# Altium schematic sheet Temp Sensor_DAC_Speed_Monitor.SchDoc
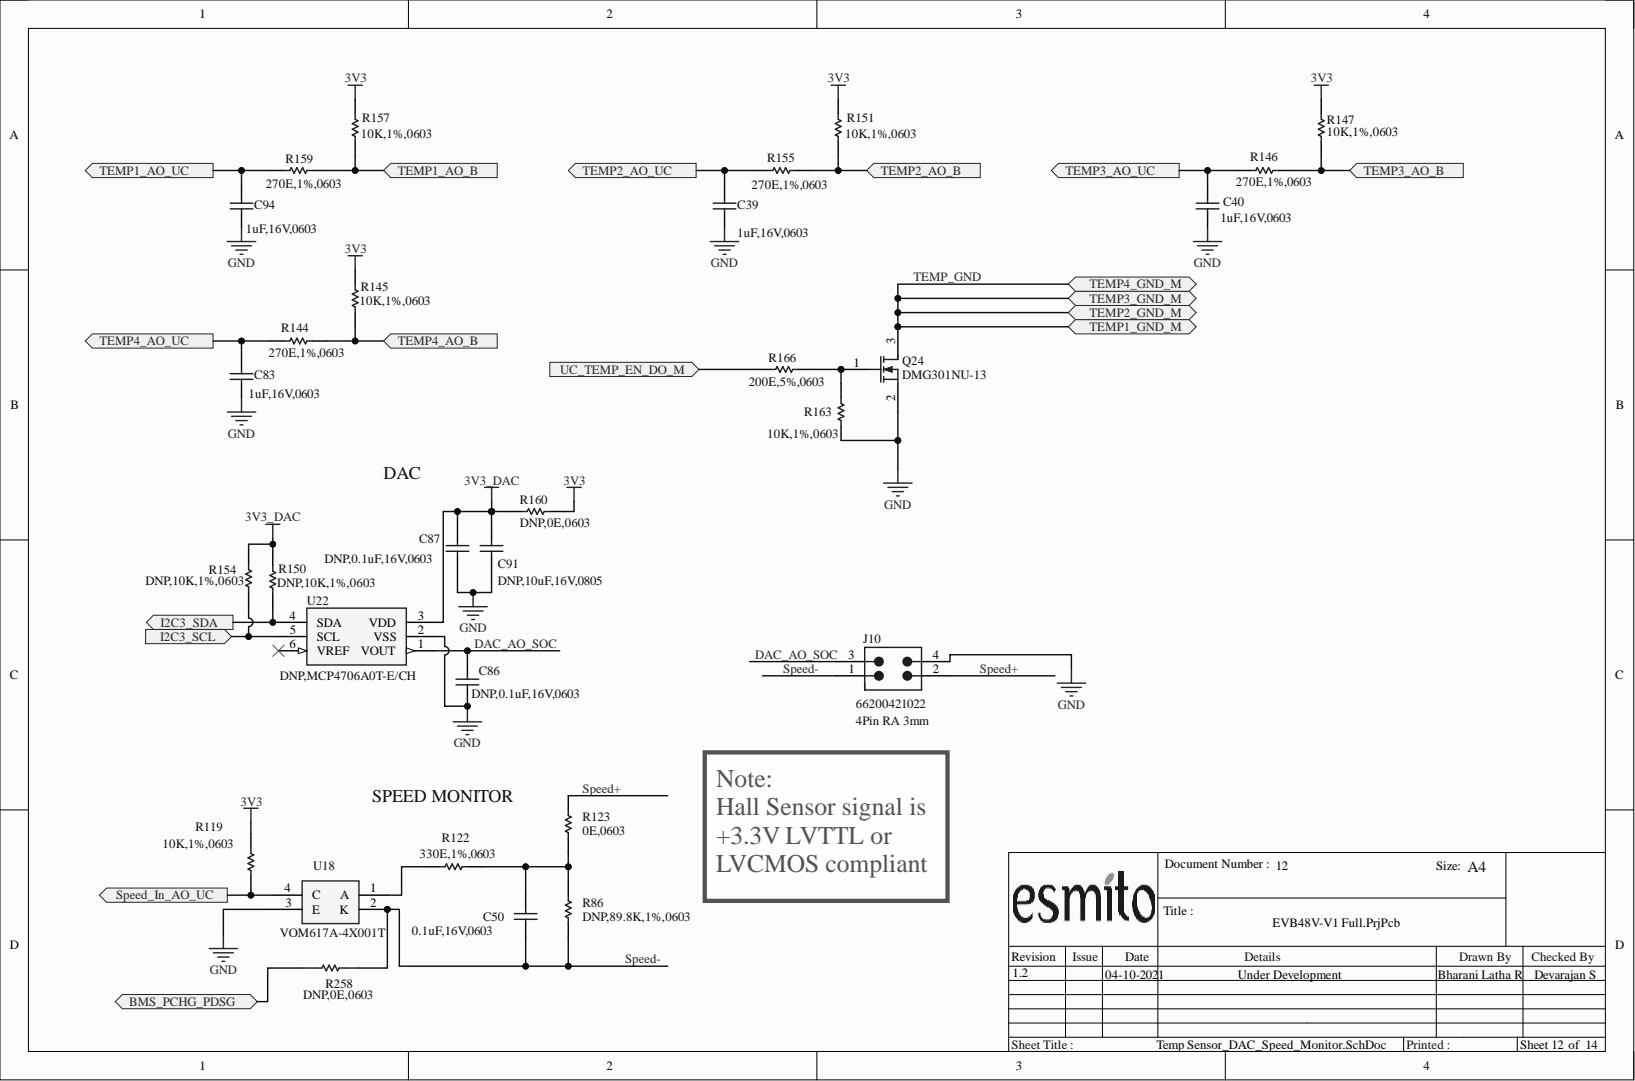
[diagram p0: Temp Sensor_DAC_Speed_Monitor.SchDoc]

## Parts
C39  value 1uF,16V,0603  [0603_C_M]  (hidden comment: C_Semi)
C40  value 1uF,16V,0603  [0603_C_M]  (hidden comment: C_Semi)
C50  value 0.1uF,16V,0603  [0603_C_M]  (hidden comment: C_Semi)
C83  value 1uF,16V,0603  [0603_C_M]  (hidden comment: C_Semi)
C86  value DNP,0.1uF,16V,0603  [0603_C_M]  (hidden comment: C_Semi)
C87  value DNP,0.1uF,16V,0603  [0603_C_M]  (hidden comment: C_Semi)
C91  value DNP,10uF,16V,0805  [0805_R_M]  (hidden comment: C_Semi)
C94  value 1uF,16V,0603  [0603_C_M]  (hidden comment: C_Semi)
J10  4Pin RA 3mm  value 66200421022  [66200421022]
Q24  value DMG301NU-13  Part Number: DMG301NU-13  [SOT23_3_M]  (hidden comment: MOS-N_E)
R86  value DNP,89.8K,1%,0603  [0603_R_M]  (hidden comment: R_Semi)
R119  value 10K,1%,0603  [0603_R_M]  (hidden comment: R_Semi)
R122  value 330E,1%,0603  [0603_R_M]  (hidden comment: R_Semi)
R123  value 0E,0603  [0603_R_M]  (hidden comment: R_Semi)
R144  value 270E,1%,0603  [0603_R_M]  (hidden comment: R_Semi)
R145  value 10K,1%,0603  [0603_R_M]  (hidden comment: R_Semi)
R146  value 270E,1%,0603  [0603_R_M]  (hidden comment: R_Semi)
R147  value 10K,1%,0603  [0603_R_M]  (hidden comment: R_Semi)
R150  value DNP,10K,1%,0603  [0603_R_M]  (hidden comment: R_Semi)
R151  value 10K,1%,0603  [0603_R_M]  (hidden comment: R_Semi)
R154  value DNP,10K,1%,0603  [0603_R_M]  (hidden comment: R_Semi)
R155  value 270E,1%,0603  [0603_R_M]  (hidden comment: R_Semi)
R157  value 10K,1%,0603  [0603_R_M]  (hidden comment: R_Semi)
R159  value 270E,1%,0603  [0603_R_M]  (hidden comment: R_Semi)
R160  value DNP,0E,0603  [0603_R_M]  (hidden comment: R_Semi)
R163  value 10K,1%,0603  [0603_R_M]  (hidden comment: R_Semi)
R166  value 200E,5%,0603  [0603_R_M]  (hidden comment: R_Semi)
R258  value DNP,0E,0603  [0603_R_M]  (hidden comment: R_Semi)
U18  value VOM617A-4X001T  Part Number: VOM617A-4X001T  [VOM617A8X001T]  (hidden comment: VOM617A-4T)
U22  MCP4706A0T-E_CH  value DNP,MCP4706A0T-E/CH  [SOT95P280X145-6N]

## Ports
BMS_PCHG_PDSG, I2C3_SCL, I2C3_SDA, Speed_In_AO_UC, TEMP1_AO_B, TEMP1_AO_UC, TEMP1_GND_M, TEMP2_AO_B, TEMP2_AO_UC, TEMP2_GND_M, TEMP3_AO_B, TEMP3_AO_UC, TEMP3_GND_M, TEMP4_AO_B, TEMP4_AO_UC, TEMP4_GND_M, UC_TEMP_EN_DO_M

## Nets
3V3: R119.1 R145.2 R147.2 R151.2 R157.2 R160.2
3V3_DAC: C87.2 C91.1 R150.2 R154.2 R160.1 U22.3(VDD)
GND: C39.1 C40.1 C83.1 C86.2 C87.1 C91.2 C94.1 J10.4 Q24.2 R163.2 U18.3(E) U22.2(VSS)
BMS_PCHG_PDSG: R258.2 ; port BMS_PCHG_PDSG
DAC_AO_SOC: C86.1 J10.3 U22.1(VOUT)
I2C3_SCL: R154.1 U22.5(SCL) ; port I2C3_SCL
I2C3_SDA: R150.1 U22.4(SDA) ; port I2C3_SDA
Speed+: J10.2 R123.2
Speed-: C50.2 J10.1 R86.1 R258.1 U18.2(K)
Speed_In_AO_UC: R119.2 U18.4(C) ; port Speed_In_AO_UC
TEMP1_AO_B: R157.1 R159.2 ; port TEMP1_AO_B
TEMP1_AO_UC: C94.2 R159.1 ; port TEMP1_AO_UC
TEMP2_AO_B: R151.1 R155.2 ; port TEMP2_AO_B
TEMP2_AO_UC: C39.2 R155.1 ; port TEMP2_AO_UC
TEMP3_AO_B: R146.2 R147.1 ; port TEMP3_AO_B
TEMP3_AO_UC: C40.2 R146.1 ; port TEMP3_AO_UC
TEMP4_AO_B: R144.2 R145.1 ; port TEMP4_AO_B
TEMP4_AO_UC: C83.2 R144.1 ; port TEMP4_AO_UC
TEMP_GND: Q24.3 ; port TEMP1_GND_M, port TEMP2_GND_M, port TEMP3_GND_M, port TEMP4_GND_M
UC_TEMP_EN_DO_M: R166.1 ; port UC_TEMP_EN_DO_M
NetC50_1: C50.1 R86.2 R122.2 R123.1
NetQ24_1: Q24.1 R163.1 R166.2
NetR122_1: R122.1 U18.1(A)

Not connected: U22 6(VREF)

## Library data of the parts
C39 C40 C83 C94 = C_Semi: MLCC - 0603 1uF 16V X5R 10%; Alternate Manufacturer: Murata; Alternate Part Number: GCM188R71C105KA64D; Manufacturer: Yageo; Part Number: CC603KRX5R7BB105; Component Kind: Standard; Signal Integrity: Cap Semi; Simulation: CAP; Library Name: Grinntech_Global_Library.IntLib
C50 C86 C87 = C_Semi: MLCC - 0603 100nF 16V X7R 10%; Alternate Manufacturer: AVX; Alternate Part Number: 0603YC104K4T2A; Manufacturer: Yageo; Part Number: CC603KRX7R7BB104; Component Kind: Standard; Signal Integrity: Cap Semi; Simulation: CAP; Library Name: Grinntech_Global_Library.IntLib
C91 = C_Semi: MLCC - 0805 16V 10uF X7R 10 %; Alternate Manufacturer: Taiyo Yuden; Alternate Part Number: EMK212BB7106KG-T; Manufacturer: Yageo; Part Number: CC0805KKX7R7BB106; Component Kind: Standard; Signal Integrity: Cap Semi; Simulation: CAP; Library Name: Grinntech_Global_Library.IntLib
J10 = 66200421122: WR-MPC3 3mmMale Angd 4Pin DualRw PlstPegs; Manufacturer: Wurth Elektronik; Component Kind: Standard; Part Number: 66200421022; Library Name: CLVESB-R10.SCHLIB
Q24 = MOS-N_E: MOSFET, N-CH, 25V, 260mA, SOT-23-3; Manufacturer: Diodes Incorporated; Component Kind: Standard; Simulation: NMOS; Library Name: CLVESB-R10.SCHLIB
R86 = R_Semi: R, THK FLM, 89.8K, 1%, 100mW, 0603; Manufacturer: Vishay; Part Number: TNPW060389K8BEEA; Component Kind: Standard; Signal Integrity: Res Semi; Simulation: RES; Library Name: Grinntech_Global_Library.IntLib
R119 R145 R147 R150 R151 R154 R157 R163 = R_Semi: R, THK FLM, 10K, 1%, 100mW, 0603; Alternate Manufacturer: Vishay; Alternate Part Number: CRCW060310K0FKEA; Manufacturer: Yageo; Part Number: RC0603FR-0710KL; Component Kind: Standard; Signal Integrity: Res Semi; Simulation: RES; Library Name: Grinntech_Global_Library.IntLib
R122 = R_Semi: R, THK FLM, 330E, 1%, 100mW, 0603; Manufacturer: Yageo; Part Number: RC0603FR-07330RL; Component Kind: Standard; Signal Integrity: Res Semi; Simulation: RES; Library Name: Grinntech_Global_Library.IntLib
R123 R160 = R_Semi: R, THK FLM, 0E,100mW,  0603; Alternate Manufacturer: Vishay; Alternate Part Number: CRCW06030000Z0EAC; Manufacturer: Yageo; Part Number: RC0603FR-070RL; Component Kind: Standard; Signal Integrity: Res Semi; Simulation: RES; Library Name: Grinntech_Global_Library.IntLib
R144 R146 R155 R159 = R_Semi: R, THK FLM, 270E, 1%, 100mW, 0603; Alternate Manufacturer: KOA; Alternate Part Number: RK73H1JTTD2700F; Manufacturer: Yageo; Part Number: RC0603FR-10270RL; Component Kind: Standard; Signal Integrity: Res Semi; Simulation: RES; Library Name: Grinntech_Global_Library.IntLib
R166 = R_Semi: R, THK FLM, 200E, 5%, 100mW, 0603; Manufacturer: Bourns; Part Number: CR0603-JW-201GLF; Component Kind: Standard; Signal Integrity: Res Semi; Simulation: RES; Library Name: Grinntech_Global_Library.IntLib
R258 = R_Semi_R245: R, THK FLM, 0E,100mW,  0603; Alternate Manufacturer: Vishay; Alternate Part Number: CRCW06030000Z0EAC; Manufacturer: Yageo; Part Number: RC0603FR-070RL; Component Kind: Standard; Library Name: CLVESB-R10.SCHLIB
U18 = VOM617A-4T: Transistor Output Optocouplers 80V Vceo; Alternate Manufacturer: Vishay; Alternate Part Number: VOMA617A-4X001T; Component Kind: Standard; Manufacturer: Vishay
U22 = MCP4706A0T-E_CH: DAC Sngl 8B NV DAC w/Ext Vref & I2C interface; Manufacturer: Microchip; Part Number: MCP4706A0T-E/CH; Component Kind: Standard
